# Altium schematic sheet SH_SFW2_V3.0.SchDot
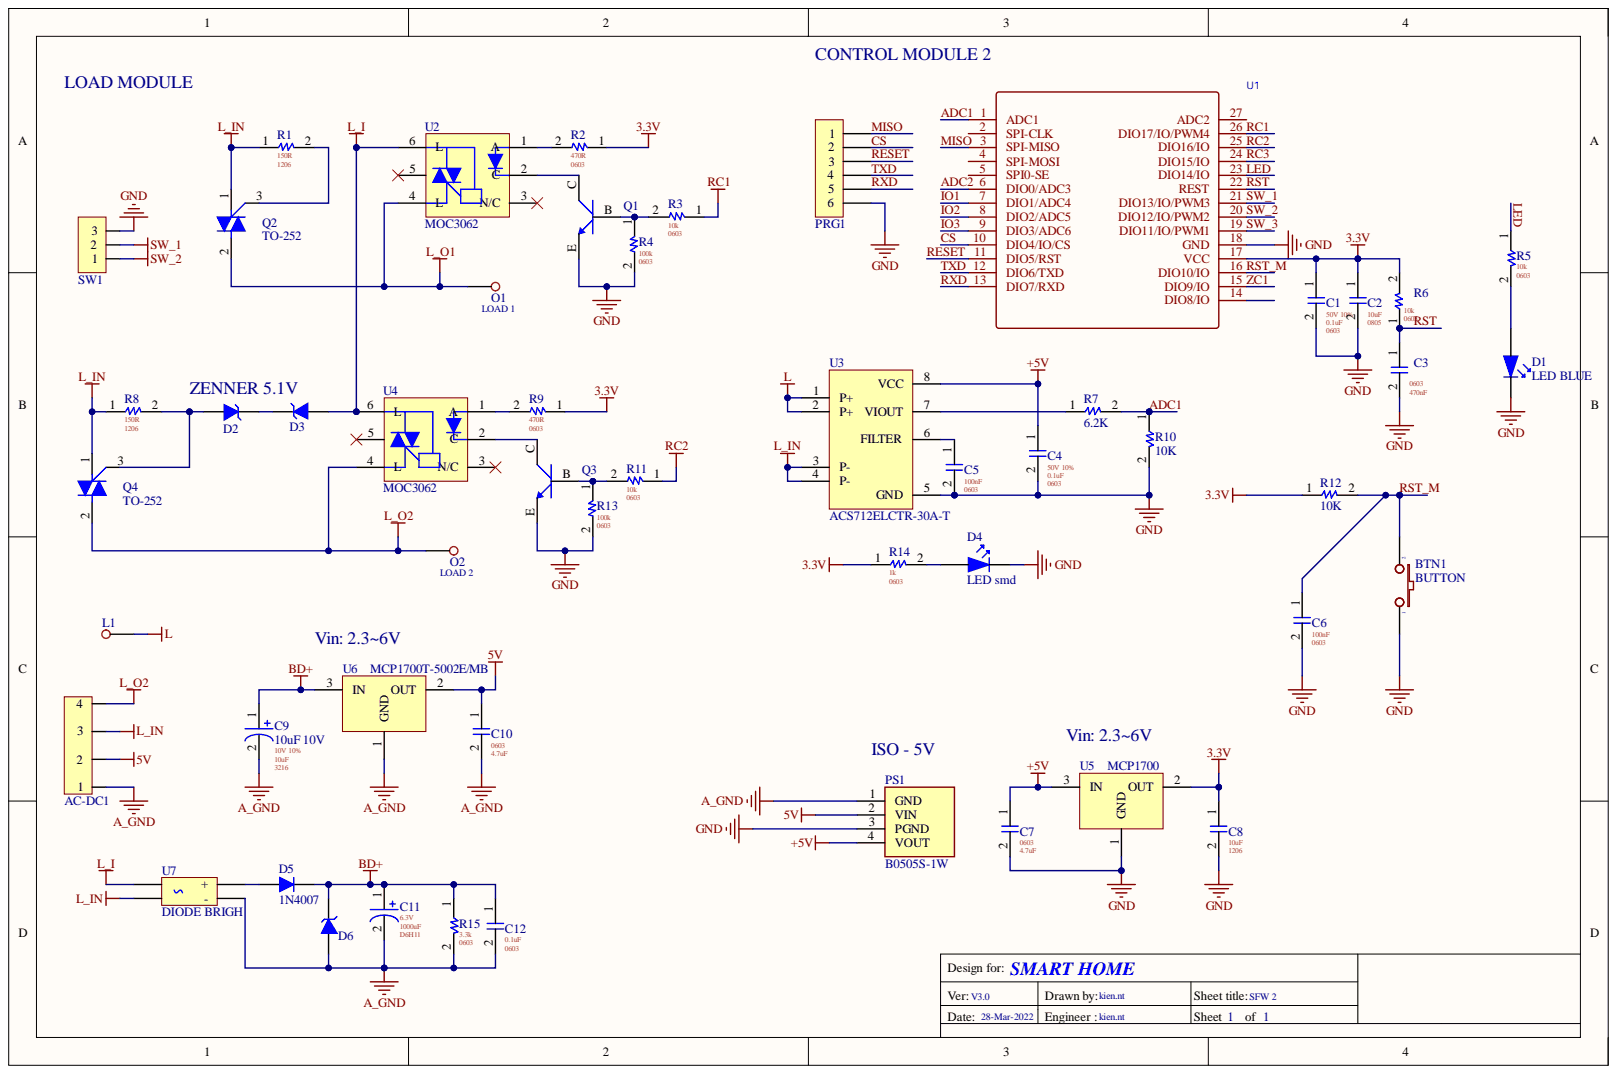
[diagram p0: SH_SFW2_V3.0.SchDot]

## Parts
AC-DC1  P4  [AC-DC 5V K4H24]  (value not drawn)
BTN1  BUTTON  [SW6X3.5mm SMD]
C1  0.1uF  FP: 0603  [0603 C]
C2  10uF  FP: 0805  [0805 C]
C3  470nF  FP: 0603  [0603 C]
C4  0.1uF  FP: 0603  VOLTAGE + TOLE: 50V 10%  [0603 C]
C5  value 100nF  FP: 0603  [0603 C]  (hidden comment: 1nF)
C6  value 100nF  FP: 0603  [0603 C]  (hidden comment: 1nF)
C7  4.7uF  FP: 0603  [0603 C]
C8  10uF  FP: 1206  [1206 C]
C9  10uF 10V  value 10uF  FP: 3216  [CAP-TAN 3216]
C10  4.7uF  FP: 0603  [0603 C]
C11  1000uF 6.3V  value 1000uF  FP: D6H11  VOLTAGE + TOLE: 6.3V  [CAP-AE 6.3x11 DIP2.54]
C12  0.1uF  FP: 0603  [0603 C]
D1  LED BLUE  [LED0603_1608]
D2  5.1V  [SOD-80C]
D3  5.1V  [SOD-80C]
D4  LED smd  [LED0603_1608]
D5  1N4007  [DO214AC]
D6  5.1V  [SOD-80C]  (value not drawn)
L1  P1  [HOLE AC]  (value not drawn)
O1  P1  [HOLE AC]  (value not drawn)
O2  P1  [HOLE AC]  (value not drawn)
PRG1  P6  [P6 - 1.27MM]  (value not drawn)
PS1  B0505S-1W  [B0505S1W]
Q1  MMBT3904  [SOT 23-3 - BCE]  (value not drawn)
Q2  TO-252  [TO-252]
Q3  MMBT3904  [SOT 23-3 - BCE]  (value not drawn)
Q4  TO-252  [TO-252]
R1  150R  FP: 1206  [1206 R]
R2  470R  [0603 R]
R3  10k  FP: 0603  [0603 R]
R4  100k  FP: 0603  [0603 R]
R5  10k  FP: 0603  [0603 R]
R6  10k  FP: 0603  [0603 R]
R7  6.2K  [0603 R]
R8  150R  FP: 1206  [1206 R]
R9  470R  FP: 0603  [0603 R]
R10  10K  [0603 R]
R11  10k  FP: 0603  [0603 R]
R12  10K  [0603 R]
R13  100k  FP: 0603  [0603 R]
R14  1k  FP: 0603  [0603 R]
R15  3.3k  FP: 0603  [0603 R]
SW1  P3  [JST 3PIN - 2.54mm]  (value not drawn)
U1  (no value)  [NXP-E75-10S]
U2  MOC3062  [6-PIN DIP]
U3  ACS712ELCTR-30A-T  [SOIC 8]
U4  MOC3062  [6-PIN DIP]
U5  MCP1700  [MCP1700]
U6  MCP1700T-5002E/MB  [MCP1700]
U7  DIODE BRIGH  [4PIN SOP]

## Nets
3.3V: C1.1 C2.1 C8.1 R2.1 R6.2 R9.1 R12.1 R14.1 U1.17(VCC) U5.2
5V: AC-DC1.2 C4.1 C7.1 C10.1 PS1.2(VIN) PS1.4(VOUT) U3.8(VCC) U5.3 U6.2
A_GND: AC-DC1.1 C9.2 C10.2 C11.2 C12.2 D6.1 PS1.1(GND) R15.2 U6.1 U7.2(-)
BD+: C9.1 C11.1 C12.1 D5.2 D6.2 R15.1 U6.3
GND: BTN1.1 C1.2 C2.2 C3.2 C4.2 C5.2 C6.2 C7.2 C8.2 D1.2 D4.2 PRG1.6 PS1.3(PGND) Q1.E Q3.E R4.2 R10.2 R13.2 SW1.3 U1.18(GND) U3.5(GND) U5.1
L: L1.1 U3.1(P+) U3.2(P+)
L_I: D3.1 U2.6(L) U4.6(L) U7.3
L_IN: AC-DC1.3 Q2.1 Q4.1 R1.1 R8.1 U3.3(P-) U3.4(P-) U7.4
L_O1: O1.1 Q2.2 U2.4(L)
L_O2: AC-DC1.4 O2.1 Q4.2 U4.4(L)
ADC1: R7.2 R10.1 U1.1(ADC1)
ADC2: U1.6(DIO0/ADC3)
CS: PRG1.2 U1.10(DIO4/IO/CS)
IO1: U1.7(DIO1/ADC4)
IO2: U1.8(DIO2/ADC5)
IO3: U1.9(DIO3/ADC6)
LED: R5.1 U1.23(DIO14/IO)
MISO: PRG1.1 U1.3(SPI-MISO)
RC1: R3.1 U1.26(DIO17/IO/PWM4)
RC2: R11.1 U1.25(DIO16/IO)
RC3: U1.24(DIO15/IO)
RESET: PRG1.3 U1.11(DIO5/RST)
RST: C3.1 R6.1 U1.22(REST)
RST_M: BTN1.2 C6.1 R12.2 U1.16(DIO10/IO)
RXD: PRG1.5 U1.13(DIO7/RXD)
SW_1: SW1.2 U1.21(DIO13/IO/PWM3)
SW_2: SW1.1 U1.20(DIO12/IO/PWM2)
SW_3: U1.19(DIO11/IO/PWM1)
TXD: PRG1.4 U1.12(DIO6/TXD)
ZC1: U1.15(DIO9/IO)
NetC5_1: C5.1 U3.6(FILTER)
NetD1_1: D1.1 R5.2
NetD2_1: D2.1 Q4.3 R8.2
NetD2_2: D2.2 D3.2
NetD4_1: D4.1 R14.2
NetD5_1: D5.1 U7.1(+)
NetQ1_B: Q1.B R3.2 R4.1
NetQ1_C: Q1.C U2.2(C)
NetQ2_3: Q2.3 R1.2
NetQ3_B: Q3.B R11.2 R13.1
NetQ3_C: Q3.C U4.2(C)
NetR2_2: R2.2 U2.1(A)
NetR7_1: R7.1 U3.7(VIOUT)
NetR9_2: R9.2 U4.1(A)

Not connected: U1 2(SPI-CLK) 4(SPI-MOSI) 5(SPI0-SE) 14(DIO8/IO) 27(ADC2); U2 3(N/C) 5; U4 3(N/C) 5

## Library data of the parts
C1 C12 = 0603 0.1uF CER: CAP CER 0.1uF 50V 10% 0603 SMD; VOLTAGE + TOLE: 50V 10%; Component Kind: Standard; ComponentLink1Description: New link; DATE: 04-Mar-21; Library Name: Capacitor.SchLib; PACKAGE: SMD; VALUE: 0.1uF; CREATOR: MMUOP
C2 = 0805 10uF CER: CAP CER 10uF 50V 10% 0805 SMD; VOLTAGE + TOLE: 50V 10%; Component Kind: Standard; ComponentLink1Description: New link; DATE: 04-Mar-21; Library Name: Capacitor.SchLib; PACKAGE: SMD; VALUE: 10uF; CREATOR: MMUOP
C3 = 0603 470nF CER: CAP CER 470nF 50V 10% 0603 SMD; VOLTAGE + TOLE: 50V 10%; Component Kind: Standard; ComponentLink1Description: New link; DATE: 04-Mar-21; Library Name: Capacitor.SchLib; PACKAGE: SMD; VALUE: 470nF; CREATOR: MMUOP
C4 = 0603 0.1uF CER: CAP CER 0.1uF 50V 10% 0603 SMD; Component Kind: Standard; ComponentLink1Description: New link; DATE: 04-Mar-21; Library Name: Capacitor.SchLib; PACKAGE: SMD; VALUE: 0.1uF; CREATOR: MMUOP
C5 C6 = 0603 1nF CER: CAP CER 1nF 50V 10% 0603 SMD; VOLTAGE + TOLE: 50V 10%; Component Kind: Standard; ComponentLink1Description: New link; DATE: 04-Mar-21; Library Name: Capacitor.SchLib; PACKAGE: SMD; CREATOR: MMUOP
C7 C10 = 0603 4.7uF CER: CAP CER 4.7uF 50V 10% 0603 SMD; VOLTAGE + TOLE: 50V 10%; Component Kind: Standard; ComponentLink1Description: New link; DATE: 04-Mar-21; Library Name: Capacitor.SchLib; PACKAGE: SMD; VALUE: 4.7uF; CREATOR: MMUOP
C8 = 1206 10uF CER: CAP CER 10uF 50V 10% 0805 SMD; VOLTAGE + TOLE: 50V 10%; Component Kind: Standard; ComponentLink1Description: New link; DATE: 04-Mar-21; Library Name: Capacitor.SchLib; PACKAGE: SMD; VALUE: 10uF; CREATOR: MMUOP
C9 = CAP-TAN 3216 SMD_2: CAP-TAN 10uF 10V 10% 3216 SMD; VOLTAGE + TOLE: 10V 10%; Component Kind: Standard; ComponentLink1Description: New link; ComponentLink1URL: https://www.thegioiic.com/products/tu-tantalum-10uf-10v-1206-taja106k010rnj; DATE: 04-Mar-21; Library Name: Capacitor.SchLib; PACKAGE: SMD; CREATOR: MMUOP
C11 = CAP-AE 6.3X11mm TH: CAP AE 1000uF 6.3V 20%  D6.3 H11 TH; Component Kind: Standard; ComponentLink1Description: New link; DATE: 04-Mar-21; Library Name: Capacitor.SchLib; PACKAGE: TH; CREATOR: MMUOP
D1 = LED smd: Component Kind: Standard; Library Name: Kienlib.SchLib
D2 D3 D6 = Diode Zener: DIODE ZENER 5.1V 1W; Editor: MMUOP; Component Kind: Standard; Library Name: Kienlib.SchLib
D5 = Diode schottky: Component Kind: Standard; Library Name: Kienlib.SchLib
PS1 = B0505S-1W: Power Supply; Manufacturer_Name: Mornsun; Component Kind: Standard; Height: 10.41mm; Library Name: SamacSys.SchLib; Manufacturer_Part_Number: B0505S-1W
Q1 Q3 = TRANS NPN_BCE: Component Kind: Standard; Library Name: Kienlib.SchLib
Q2 Q4 = TRIAC: Component Kind: Standard; Library Name: Kienlib.SchLib
R1 R8 = 1206 150R 1%: 150R 1% 1206 SMD; Component Kind: Standard; ComponentLink1Description: New link; DATE: 04-Mar-21; Library Name: Res.SchLib; PACKAGE: SMD; VALUE: 150R; VOLTAGE + TOLE: 1%; CREATOR: MMUOP
R2 = 0603 470R 1%: 470R 1% 0603 SMD; FP: 0603; Component Kind: Standard; ComponentLink1Description: New link; DATE: 04-Mar-21; Library Name: Res.SchLib; PACKAGE: SMD; VALUE: 470R; VOLTAGE + TOLE: 1%; CREATOR: MMUOP
R3 R5 R6 R11 = 0603 10k 1%: 10k 1% 0603 SMD; PN: C98220; Component Kind: Standard; ComponentLink1Description: New link; DATE: 04-Mar-21; Library Name: Res.SchLib; PACKAGE: SMD; VALUE: 10k; VOLTAGE + TOLE: 1%; CREATOR: MMUOP
R4 R13 = 0603 100k 1%: 100k 1% 0603 SMD; Component Kind: Standard; ComponentLink1Description: New link; DATE: 04-Mar-21; Library Name: Res.SchLib; PACKAGE: SMD; VALUE: 100k; VOLTAGE + TOLE: 1%; CREATOR: MMUOP
R7 R10 R12 = Res SMD: RES SMD 1K OHM 1% 0.15W 0603; Component Kind: Standard; Library Name: Kienlib.SchLib
R9 = 0603 470R 1%: 470R 1% 0603 SMD; Component Kind: Standard; ComponentLink1Description: New link; DATE: 04-Mar-21; Library Name: Res.SchLib; PACKAGE: SMD; VALUE: 470R; VOLTAGE + TOLE: 1%; CREATOR: MMUOP
R14 = 0603 1k 1%: 1k 1% 0603 SMD; Component Kind: Standard; ComponentLink1Description: New link; DATE: 04-Mar-21; Library Name: Res.SchLib; PACKAGE: SMD; VALUE: 1k; VOLTAGE + TOLE: 1%; CREATOR: MMUOP
R15 = 0603 3.3k 1%: 3.3k 1% 0603 SMD; Component Kind: Standard; ComponentLink1Description: New link; DATE: 04-Mar-21; Library Name: Res.SchLib; PACKAGE: SMD; VALUE: 3.3k; VOLTAGE + TOLE: 1%; CREATOR: MMUOP
U1 = E75-2G4M10S: Component Kind: Standard
U2 U4 = TRIAC & SCR: Component Kind: Standard; Library Name: Kienlib.SchLib
U3 = ACS71240: ACS712ELCTR-20A  SOIC 8; Component Kind: Standard; ComponentLink1Description: New link; ComponentLink1URL: https://banlinhkiendientu.vn/acs712t/; Library Name: Kienlib.SchLib
U6 = MCP1700: Component Kind: Standard; Library Name: Kienlib.SchLib
AC-DC1 = P4: Component Kind: Standard; Library Name: Kienlib.SchLib
BTN1 = BUTTON: Component Kind: Standard; Library Name: Library V1.0.IntLib
D4 = LED smd: Component Kind: Standard; Library Name: Kienlib.SchLib
L1 O1 O2 = P1: Component Kind: Standard; Library Name: Kienlib.SchLib
PRG1 = P6: Component Kind: Standard; Library Name: Kienlib.SchLib
SW1 = P3: Component Kind: Standard; Library Name: Kienlib.SchLib
U5 = MCP1700: Component Kind: Standard; Library Name: Kienlib.SchLib
U7 = DIODE BRIGH: Component Kind: Standard; Library Name: Kienlib.SchLib
